# Altium schematic sheet main.SchDoc(main)
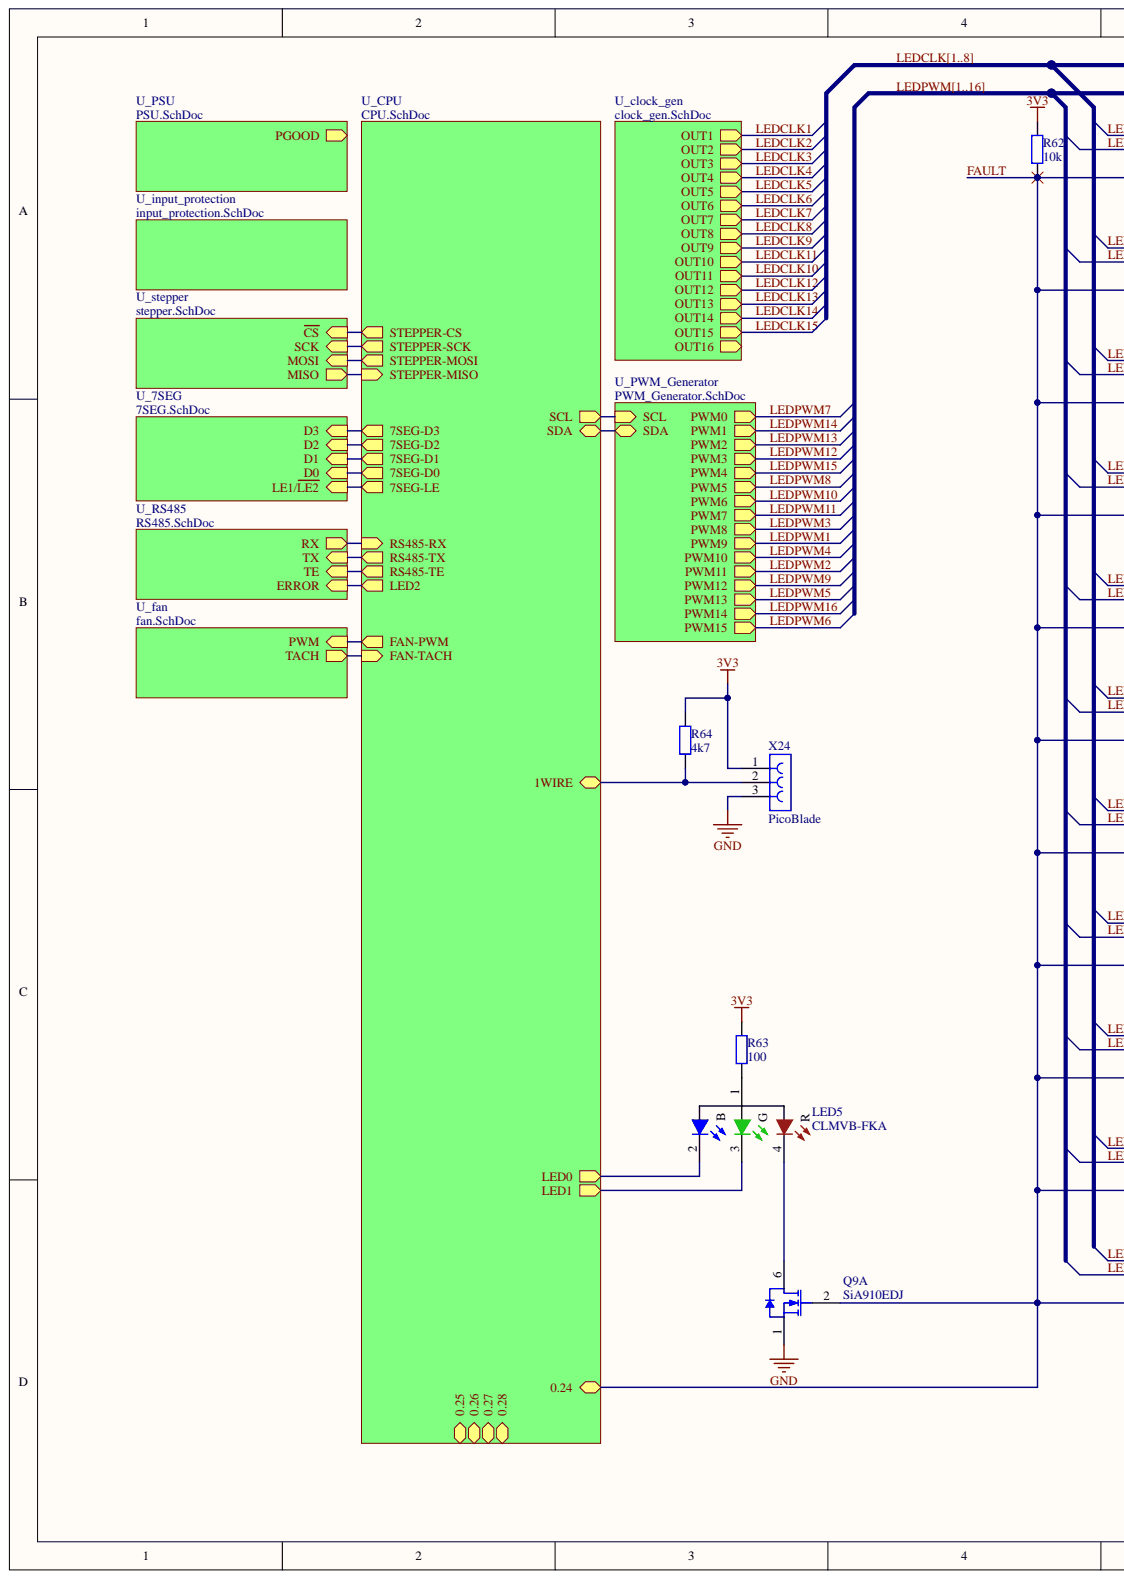
[diagram p0: main.SchDoc, left]
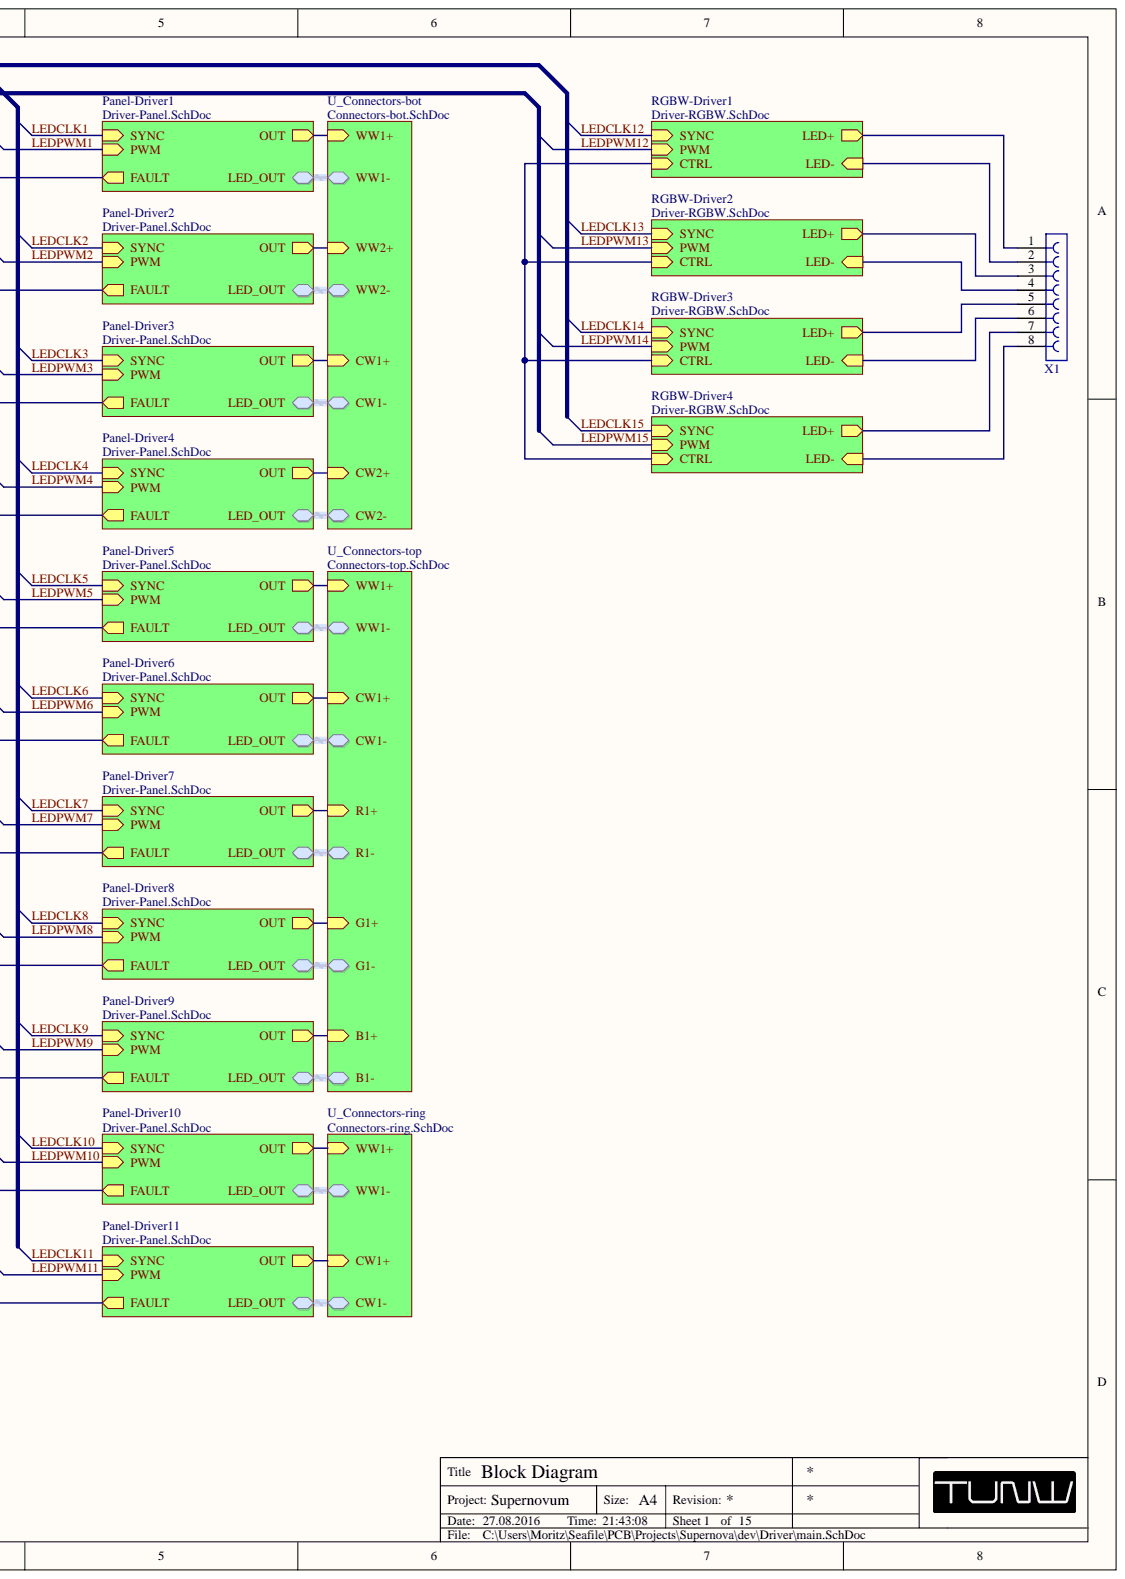
[diagram p1: main.SchDoc, right]

## Parts
LED5  CLMVB-FKA  [uLED]
Q9  SiA910EDJ  [SC70-6L-DUAL]  (part A)
R62  10k  [R-0402-M]
R63  100  [R-0402-M]
R64  4k7  [R-0402-M]
X1  PicoBlade  [PICOBLADE-8P-RA-SMD]
X24  PicoBlade  [PICOBLADE-3P-SMD]

## Nets
3V3: R62.2 R63.2 R64.2 X24.1
GND: Q9.1 X24.3
FAULT: Q9.2 R62.1
NetLED5_1: LED5.1 R63.1
NetLED5_2: LED5.2
NetLED5_3: LED5.3
NetLED5_4: LED5.4 Q9.6
NetR64_1: R64.1 X24.2
NetX1_1: X1.1
NetX1_2: X1.2
NetX1_3: X1.3
NetX1_4: X1.4
NetX1_5: X1.5
NetX1_6: X1.6
NetX1_7: X1.7
NetX1_8: X1.8
0.25:
0.26:
0.27:
0.28:
CTRL:
D0:
D1:
D2:
D3:
FAN-PWM:
FAN-TACH:
LE1/~{LE2}:
LED2:
LED_OUT.1:
LED_OUT.2:
LED_OUT.3:
LED_OUT.4:
LED_OUT.5:
LED_OUT.6:
LED_OUT.7:
LED_OUT.8:
LEDCLK1:  [also labelled LEDCLK]
LEDCLK2:  [also labelled LEDCLK]
LEDCLK3:  [also labelled LEDCLK]
LEDCLK4:  [also labelled LEDCLK]
LEDCLK5:  [also labelled LEDCLK]
LEDCLK6:  [also labelled LEDCLK]
LEDCLK7:  [also labelled LEDCLK]
LEDCLK8:  [also labelled LEDCLK]
LEDCLK9:  [also labelled LEDCLK]
LEDCLK10:  [also labelled LEDCLK]
LEDCLK11:  [also labelled LEDCLK]
LEDCLK12:  [also labelled LEDCLK]
LEDCLK13:  [also labelled LEDCLK]
LEDCLK14:  [also labelled LEDCLK]
LEDCLK15:  [also labelled LEDCLK]
LEDPWM1:  [also labelled LEDPWM]
LEDPWM2:  [also labelled LEDPWM]
LEDPWM3:  [also labelled LEDPWM]
LEDPWM4:  [also labelled LEDPWM]
LEDPWM5:  [also labelled LEDPWM]
LEDPWM6:  [also labelled LEDPWM]
LEDPWM7:  [also labelled LEDPWM]
LEDPWM8:  [also labelled LEDPWM]
LEDPWM9:  [also labelled LEDPWM]
LEDPWM10:  [also labelled LEDPWM]
LEDPWM11:  [also labelled LEDPWM]
LEDPWM12:  [also labelled LEDPWM]
LEDPWM13:  [also labelled LEDPWM]
LEDPWM14:  [also labelled LEDPWM]
LEDPWM15:  [also labelled LEDPWM]
LEDPWM16:  [also labelled LEDPWM]
OUT:
OUT16:
PGOOD:
RS485-RX:
RS485-TE:
RS485-TX:
SCL:
SDA:
STEPPER-CS:
STEPPER-MISO:
STEPPER-MOSI:
STEPPER-SCK:

## Library data of the parts
LED5 = uLED-RGB: CLMVB-FKA RGB; Component Kind: Standard; Library Name: advanced.IntLib; Supplier 1: Mouser; Supplier Part Number 1: 941-CLMVBFKACFHEHLCB
Q9 = MOSFET-SiA910EDJ: Dual mini mosfet 12V 4.5A; Component Kind: Standard; Library Name: advanced.IntLib; Supplier 1: Mouser; Supplier Part Number 1: 781-SIA910EDJ-T1-GE3
R62 = R-0402-10k: Resistor 10k; Component Kind: Standard; Library Name: Passives.IntLib; Supplier 1: Mouser; Supplier Part Number 1: 71-CRCW0402-10K-E3
R63 = R-0402-100: Resistor 100; Component Kind: Standard; Library Name: Passives.IntLib; Supplier 1: Mouser; Supplier Part Number 1: 71-CRCW0402-100-E3
R64 = R-0402-4k7: Resistor 4k7; Component Kind: Standard; Library Name: Passives.IntLib; Supplier 1: Mouser; Supplier Part Number 1: 71-CRCW0402-4.7K-E3
X1 = PicoBlade-8P-RA-SMD: PicoBlade Connector SMD; Component Kind: Standard; Library Name: Connectors.IntLib; Supplier 1: Mouser; Supplier Part Number 1: 538-53261-0871
X24 = PicoBlade-3P-SMD: PicoBlade Connector THT; Component Kind: Standard; Library Name: Connectors.IntLib; Supplier 1: Mouser; Supplier Part Number 1: 538-53398-0371
